# Altium schematic sheet cwh_fpga_misc.SchDoc
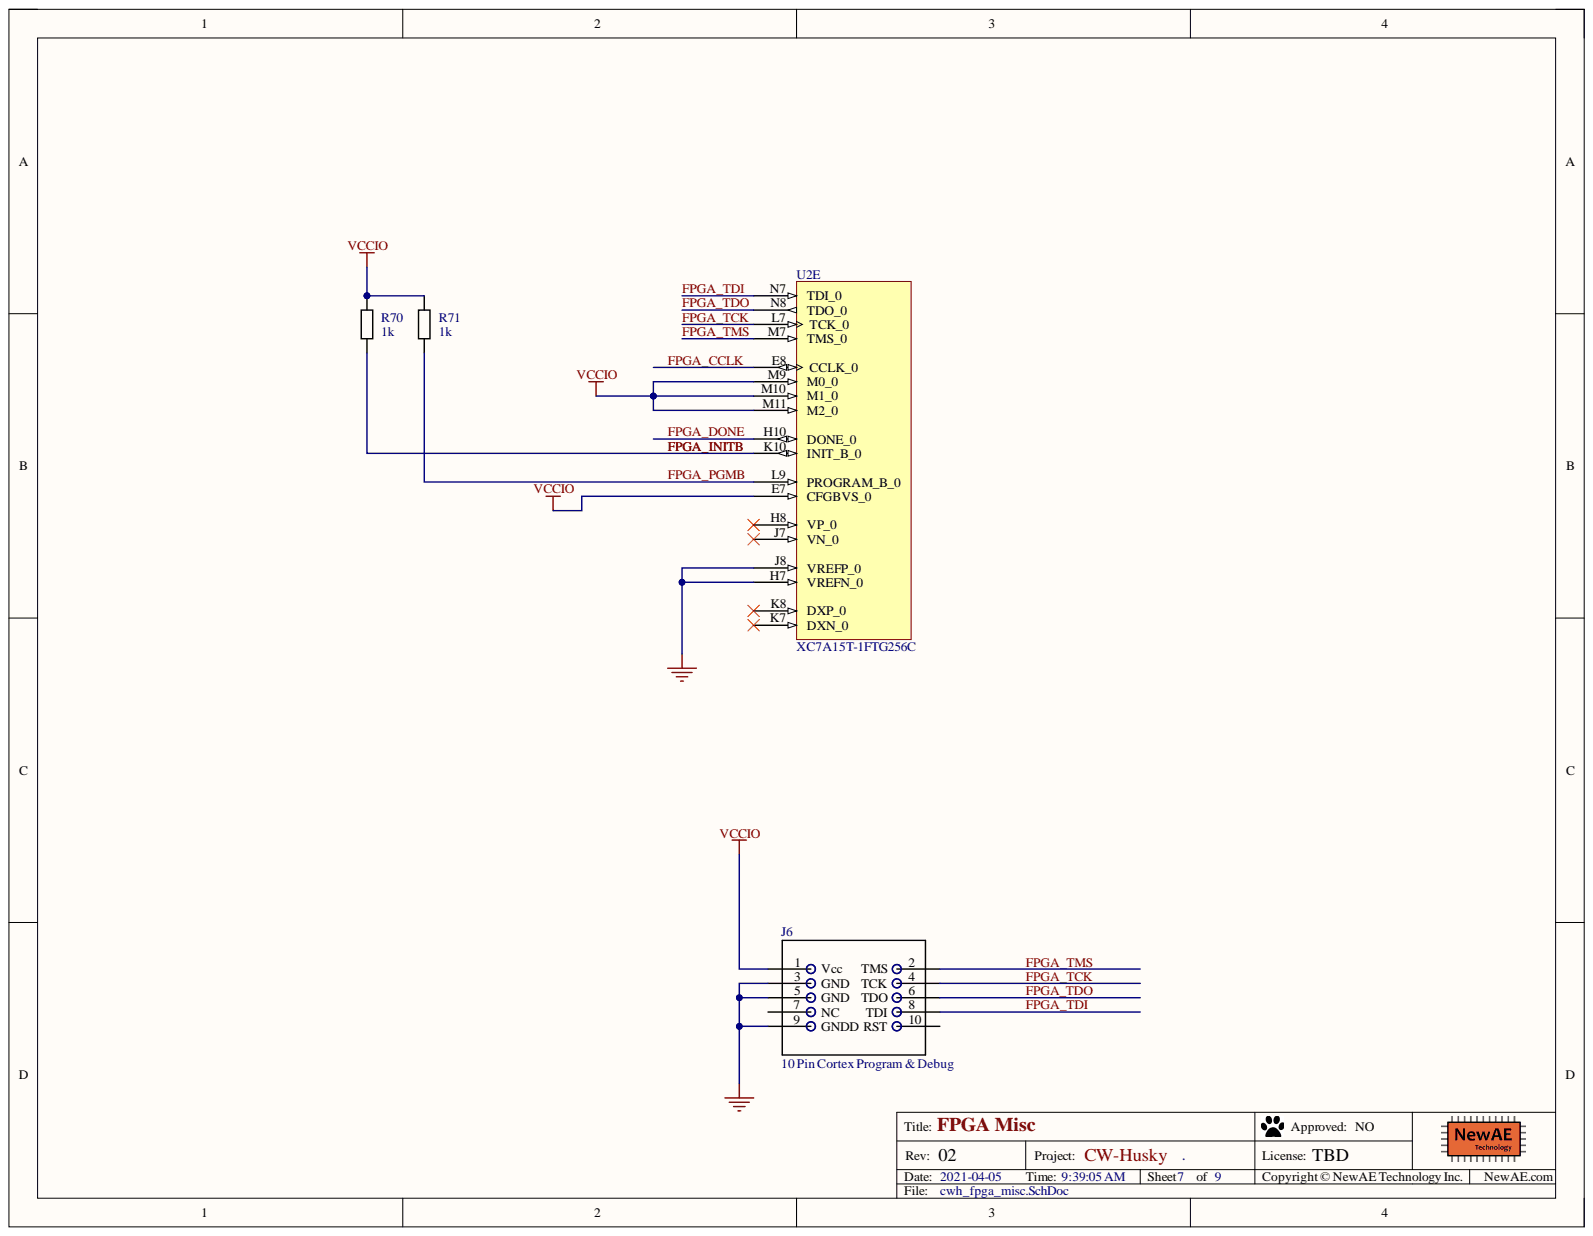
[diagram p0: cwh_fpga_misc.SchDoc]

## Parts
J6  10 Pin Cortex Program & Debug  [0.5mm_SMD_Header_5x2]
R70  1k  [0603]
R71  1k  [0603]
U2  XC7A15T-1FTG256C  [FTG256]  (part E)

## Nets
GND: J6.3(GND) J6.5(GND) J6.9(GNDD) U2.H7(VREFN_0) U2.J8(VREFP_0)
VCCIO: J6.1(Vcc) R70.1 R71.1 U2.E7(CFGBVS_0) U2.M9(M0_0) U2.M10(M1_0) U2.M11(M2_0)
FPGA_CCLK: U2.E8(CCLK_0)
FPGA_DONE: U2.H10(DONE_0)
FPGA_INITB: R70.2 U2.K10(INIT_B_0)
FPGA_PGMB: R71.2 U2.L9(PROGRAM_B_0)
FPGA_TCK: J6.4(TCK) U2.L7(TCK_0)
FPGA_TDI: J6.8(TDI) U2.N7(TDI_0)
FPGA_TDO: J6.6(TDO) U2.N8(TDO_0)
FPGA_TMS: J6.2(TMS) U2.M7(TMS_0)

Not connected: J6 7(NC) 10(RST); U2 H8(VP_0) J7(VN_0) K7(DXN_0) K8(DXP_0)

## Library data of the parts
J6 = Cortex_Debug_Header_10Pin: 10 Pin Cortex Program & Debug 0.5mm pitch; Manufacture Part Number 1: 20021121-00010C4LF; Component Group: Connector; Database Table Name: main; PP Material Stack: 0; Component Kind: Standard; Component Type: Standard; Library Name: NewAE_AltiumLib.DBLib; Mounting Technology: SMT; Part Number: Cortex_Debug_Header_10Pin; Pin Count: 10; PP Rotation: 0; RoHS: Yes; Supplier 1: Digi-Key; Supplier Part Number 1: 609-3695-1-ND
R70 R71 = RCHIP-1K-0603: 1k res, 0603, 1%, 1/10W; Manufacture 1: Yageo; Manufacture Part Number 1: RC0603FR-071KL; Case-Metric: 1608; Component Group: Resistor; Database Table Name: main; Rated Power: 0.1W; Rated Voltage: 75VDC; Case-EIA: 0603; Component Kind: Standard; Component Type: Standard; Library Name: NewAE_AltiumLib.DBLib; Mounting Technology: SMT; Part Number: RCHIP-1K-0603; Pin Count: 2; Supplier 1: Digi-Key; Supplier Part Number 1: 311-1.00KHRCT-ND; Temperature Range: -55C to 155C; Tolerance: 1%; Value: 1k
U2 = CMP-0383-00028-1: Artix-7 FPGA, 170 User I/Os, 0 GTP, 256-Ball BGA, Speed Grade 1, Commercial Grade, Pb-Free; Manufacturer: Xilinx; PartNumber: XC7A35T-1FTG256C; Available User I/O: 170; CMTs: 5; GTP: 0; Logic Cells: 33280; Speed Grades: 1; Total Block RAM: 1800 Kb; Component Kind: Standard; ComponentLink1Description: Manufacturer URL; ComponentLink1URL: http://www.xilinx.com/; ComponentLink2Description: Datasheet; ComponentLink2URL: http://www.xilinx.com/support/documentation/data_sheets/ds180_7Series_Overview.pdf; ComponentLink3Description: Pinout; ComponentLink3URL: http://www.xilinx.com/support/documentation/user_guides/ug475_7Series_Pkg_Pinout.pdf; ComponentLink4Description: Package Specification; ComponentLink4URL: http://www.xilinx.com/support/documentation/user_guides/ug475_7Series_Pkg_Pinout.pdf; DatasheetVersion: Rev. 1.15; Library Name: Altium Content Vault; Maximum Distributed RAM: 400 Kb; Mounting Technology: Surface Mount; Operating Temperature Range: Commercial; PackageDescription: 256-Ball Wire-Bond Fine-Pitch Thin BGA, Body 17 x 17 mm, Pitch 1 mm; PackageReference: FTG-256; PackageVersion: Rev. 1.11
